# Altium schematic sheet BLDC_Motor_Reverser.SchDoc(BLDC_Motor_Reverser)
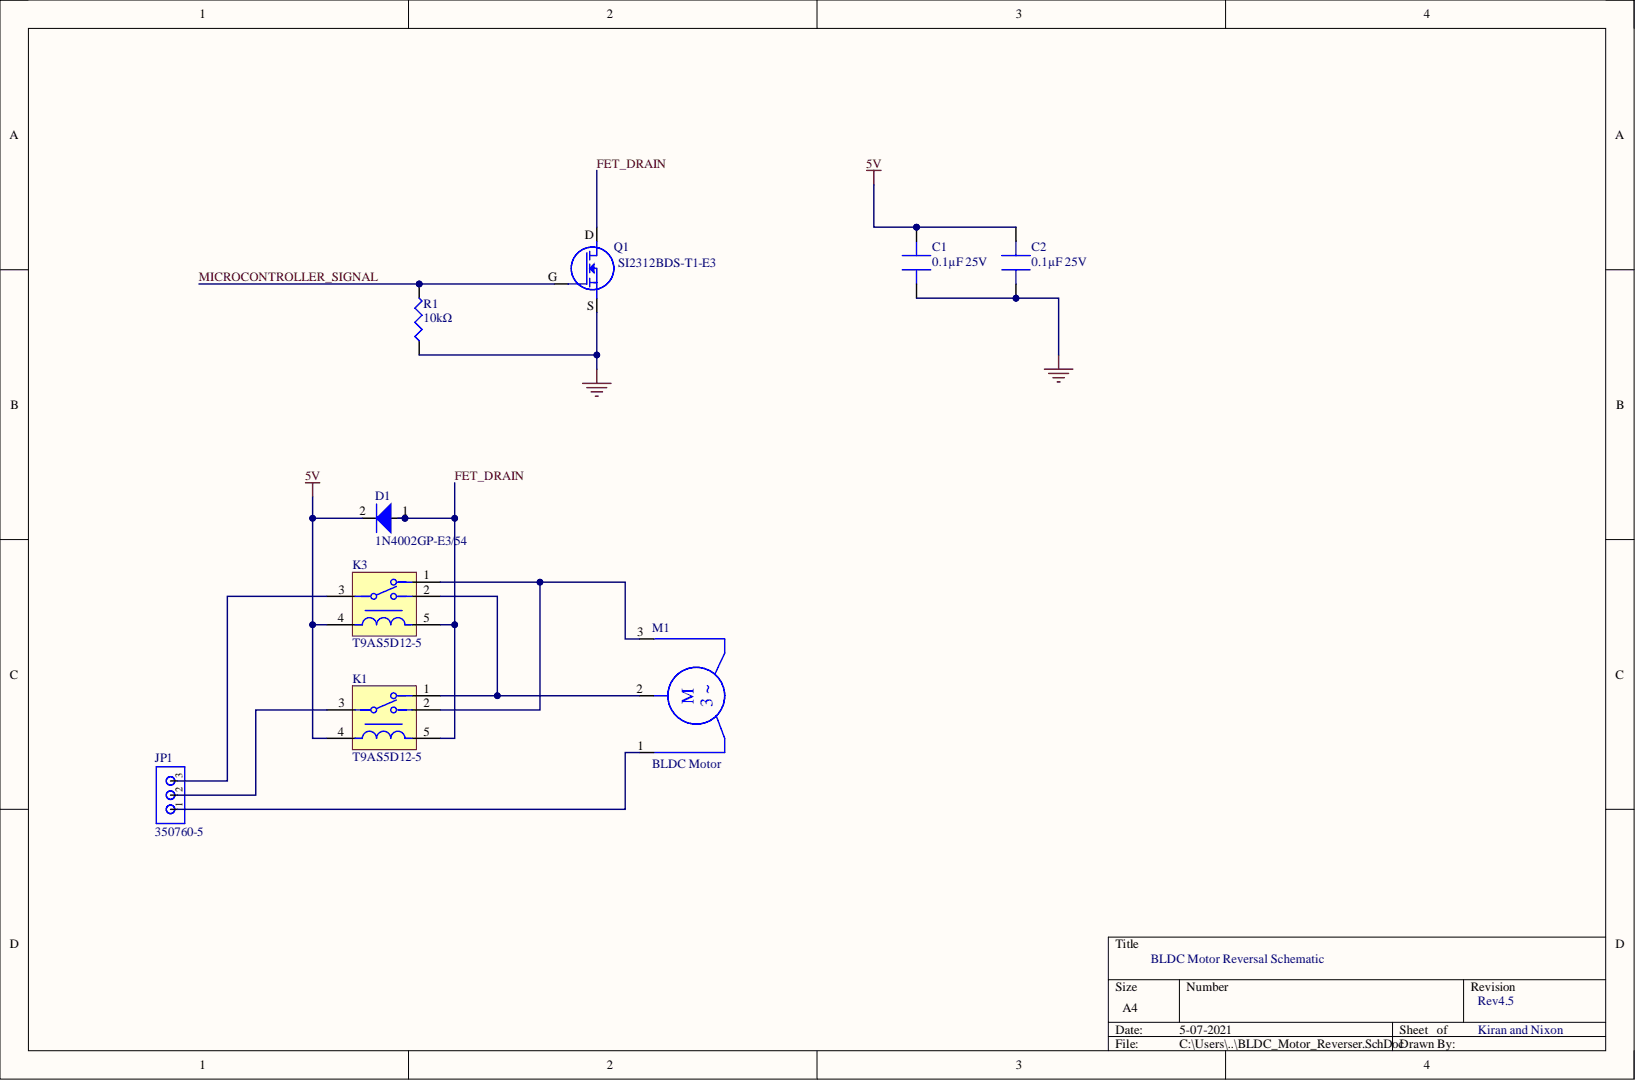
[diagram p0: BLDC_Motor_Reverser.SchDoc]

## Parts
C1  0.1µF 25V
C2  0.1µF 25V
D1  1N4002GP-E3/54
JP1  350760-5
K1  T9AS5D12-5  [FP-T9AS5D12-5-MFG]
K3  T9AS5D12-5  [FP-T9AS5D12-5-MFG]
M1  BLDC Motor
Q1  SI2312BDS-T1-E3
R1  10kΩ

## Nets
5V: C1.2 C2.2 D1.2 K1.4 K3.4
GND: C1.1 C2.1 Q1.S R1.1
FET_DRAIN: D1.1 K1.5 K3.5 Q1.D
MICROCONTROLLER_SIGNAL: Q1.G R1.2
NetJP1_1: JP1.1 M1.1
NetJP1_2: JP1.2 K1.3
NetJP1_3: JP1.3 K3.3
NetK1_1: K1.1 K3.2 M1.2
NetK1_2: K1.2 K3.1 M1.3

## Library data of the parts
C1 C2 = GCM188R71E104KA57D: CAP CER 0.1UF 25V X7R 0603; Component Kind: Standard; Library Name: BLDC_Motor_Lib.SchLib
D1 = 1N4002GP-E3/54: Flyback Diode for SPDT; Component Kind: Standard; Library Name: BLDC_Motor_Lib.SchLib
K1 K3 = T9AS5D12-5: RELAY GEN PURPOSE SPDT 20A 5V; Coil Current: 200mA; Coil Power: 1W; Coil Resistance: 25R; Coil Type: Non-Latching; Contact Voltage Rating (AC): 277V; Current Rating: 30A; Max Voltage Rating (DC): 28V; Operate Time: 15ms; Relay Type: General Purpose; Coil Voltage (DC): 5V; Component Kind: Standard; Contact Current Rating: 20A; Contact Material: Silver; Height: 20.4mm; Length: 32.5mm; Library Name: BLDC_Motor_Lib.SchLib; Max Operating Temperature: 85°C; Max Voltage Rating (AC): 277V; Min Operating Temperature: -55°C; Mount: Through Hole; Number of Pins: 5; Package Quantity: 50; Packaging: Bulk; Release Time: 15ms; RoHS Compliant: Yes; Series: T9A; Termination: PCB; Throw Configuration: SPDT; Voltage Rating (DC): 5V; Weight: 0.918oz; Width: 27.4mm
M1 = BLDC_Motor: Component Kind: Standard; Library Name: BLDC_Motor_Lib.SchLib
R1 = CR0201AJW-103GLF: 10KOhm Resistor (Pulldown Res); Component Kind: Standard; Library Name: BLDC_Motor_Lib.SchLib
JP1 = 350760-5: Component Kind: Standard; Library Name: BLDC_Motor_Lib.SchLib
Q1 = SI2312BDS-T1-E3: Component Kind: Standard; Library Name: BLDC_Motor_Lib.SchLib
